# Altium schematic sheet LoRa_RF_click_v102.SchDoc
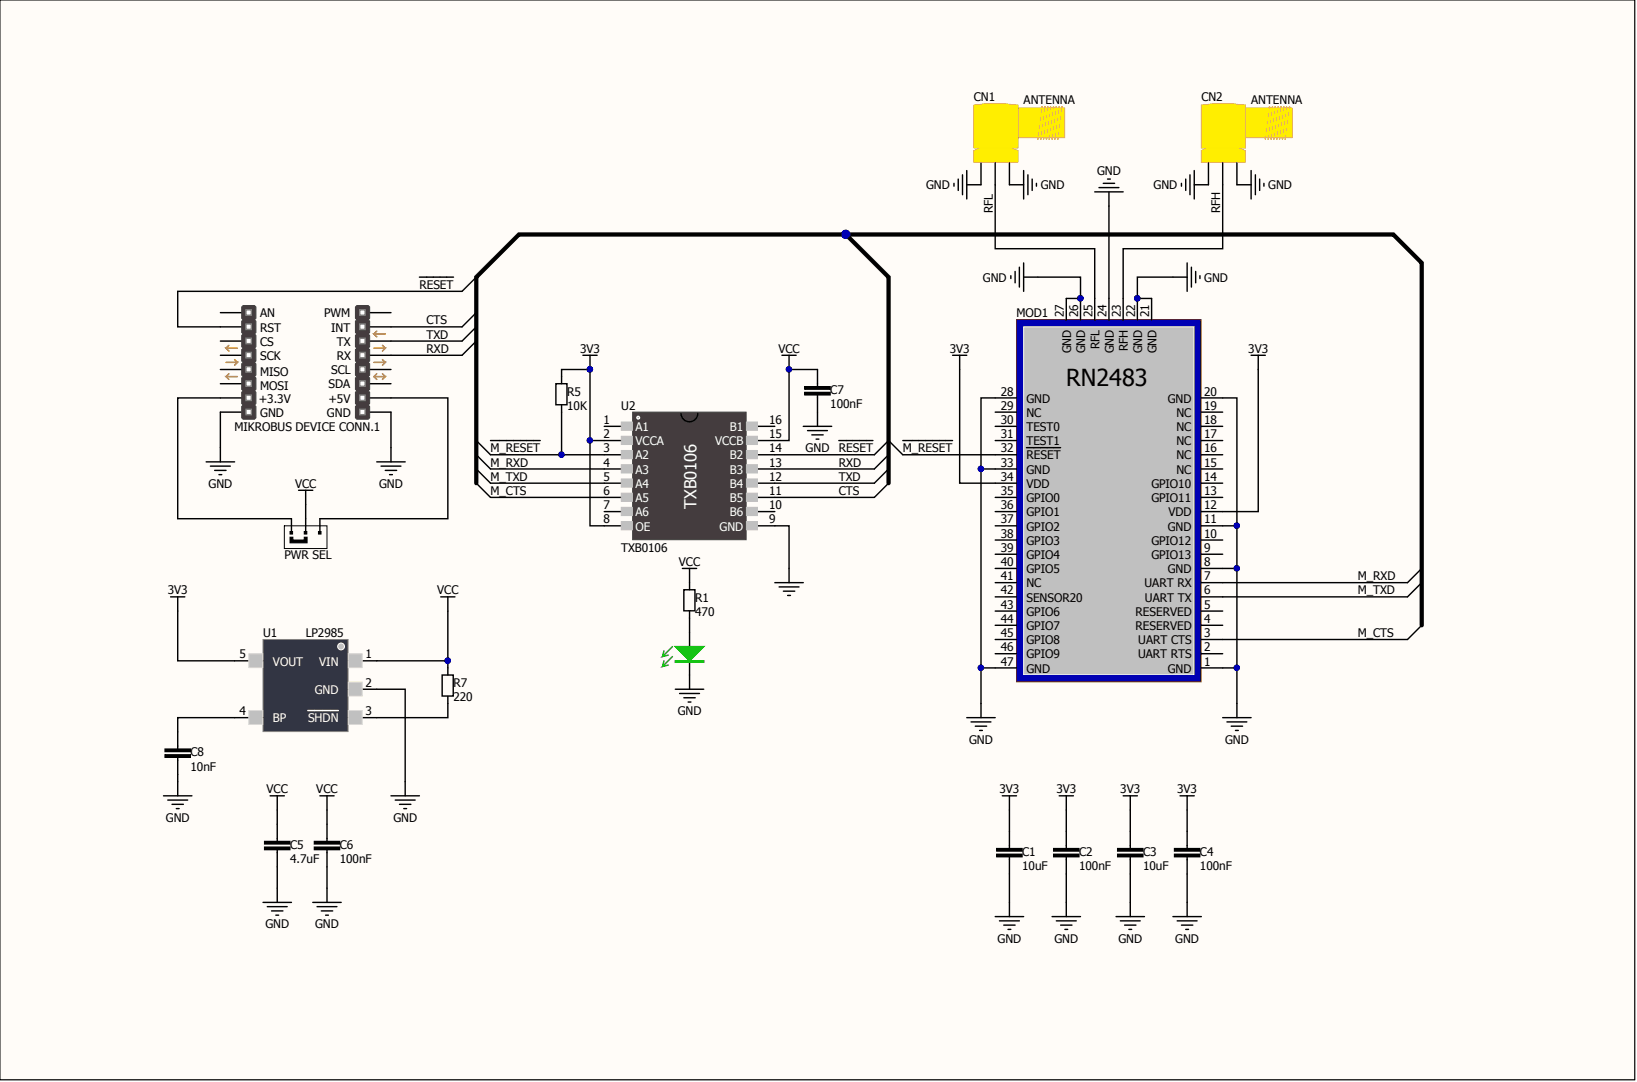
[diagram p0: LoRa_RF_click_v102.SchDoc]

## Parts
C1  10uF  [0805_C_V3]
C2  100nF  [0805_C_V3]
C3  10uF  [0805_C_V3]
C4  100nF  [0805_C_V3]
C5  4.7uF  [0805_C_V3]
C6  100nF  [0805_C_V3]
C7  100nF  [0805_C_V3]
C8  10nF  [0805_C_V3]
CN1  ANTENNA  [MCX JACK RIGH ANGLE]
CN2  ANTENNA  [MCX JACK RIGH ANGLE]
J3  PWR SEL  [0805 DUAL v2]  (part A)
MIKROBUS_DEVICE_CONN.1  MIKROBUS DEVICE CONN.  [MIKRO_BUS_LARGE]
MOD1  RN2483  [rn2483]
PWR  GREEN  [0805 - LED]  (value not drawn)  (part A)
R1  470  [0805_R_V3]
R5  10K  [0805_R_V3]
R7  220  [0805_R_V3]
U1  LP2985  [TSOT23-5]
U2  TXB0106  [TSSOP-16]

## Nets
3V3: C1.2 C2.2 C3.2 C4.2 MOD1.12(VDD) MOD1.34(VDD) R5.2 U1.5(VOUT) U2.2(VCCA) U2.8(OE)
GND: C1.1 C2.1 C3.1 C4.1 C5.1 C6.1 C7.2 C8.1 CN1.0 CN2.0 MIKROBUS_DEVICE_CONN.1.8(GND) MIKROBUS_DEVICE_CONN.1.9(GND) MOD1.1(GND) MOD1.8(GND) MOD1.11(GND) MOD1.20(GND) MOD1.21(GND) MOD1.22(GND) MOD1.24(GND) MOD1.26(GND) MOD1.27(GND) MOD1.28(GND) MOD1.33(GND) MOD1.47(GND) PWR.2 U1.2(GND) U2.9(GND)
VCC: C5.2 C6.2 C7.1 J3.2 R1.2 R7.2 U1.1(VIN) U2.15(VCCB)
CTS: MIKROBUS_DEVICE_CONN.1.15(INT) U2.11(B5)
~{M_RESET}: MOD1.32(RESET) R5.1 U2.3(A2)
M_CTS: MOD1.3(UART_CTS) U2.6(A5)
M_RXD: MOD1.7(UART_RX) U2.4(A3)
M_TXD: MOD1.6(UART_TX) U2.5(A4)
~{RESET}: MIKROBUS_DEVICE_CONN.1.2(RST) U2.14(B2)
RFH: CN2.1 MOD1.23(RFH)
RFL: CN1.1 MOD1.25(RFL)
RXD: MIKROBUS_DEVICE_CONN.1.13(RX) U2.13(B3)
TXD: MIKROBUS_DEVICE_CONN.1.14(TX) U2.12(B4)
NetC8_2: C8.2 U1.4(BP)
NetJ3_1: J3.1 MIKROBUS_DEVICE_CONN.1.7(+3.3V)
NetJ3_3: J3.3 MIKROBUS_DEVICE_CONN.1.10(+5V)
NetPWR_1: PWR.1 R1.1
NetR7_1: R7.1 U1.3(SHDN)
N00008:

Not connected: MIKROBUS_DEVICE_CONN.1 1(AN) 3(CS) 4(SCK) 5(MISO) 6(MOSI) 11(SDA) 12(SCL) 16(PWM); MOD1 2(UART_RTS) 4(RESERVED) 5(RESERVED) 9(GPIO13) 10(GPIO12) 13(GPIO11) 14(GPIO10) 15(NC) 16(NC) 17(NC) 18(NC) 19(NC) 29(NC) 30(TEST0) 31(TEST1) 35(GPIO0) 36(GPIO1) 37(GPIO2) 38(GPIO3) 39(GPIO4) 40(GPIO5) 41(NC) 42(SENSOR20) 43(GPIO6) 44(GPIO7) 45(GPIO8) 46(GPIO9); U2 1(A1) 7(A6) 10(B6) 16(B1)

## Library data of the parts
C1-C8 = CAP NP: Component Kind: Standard; SMD: Yes
  C7: Library Name: RF_click_v100.SCHLIB
  C1-C6 C8: Library Name: RTCSPI_click_v100.SchLib
CN1 CN2 = ANT_SMA: Component Kind: Standard; Description: ANT_SMA; SMD: No; Library Name: mikroE_SCH_Library_Predrag.SchLib
J3 = JUMPER2 SMD: SMD Jumper, two state; Component Kind: Standard; SMD: Yes; Library Name: AMBIENT_click_v100.SCHLIB
MIKROBUS_DEVICE_CONN.1 = MIKROBUS DEVICE CONN.: mikroBUS Target Header; Component Kind: Standard; Library Name: SCH_Rega.SchLib
MOD1 = RN2483-I/RM095: Microchip Technology Dual Band Long Range LoRa Module; Product Status: New at Mouser; Brand: Microchip Technology; Category: Microchip Technology; Component Kind: Standard; ComponentLink1Description: http://www.mouser.com/ds/2/268/70005219A-607960.pdf; ComponentLink1URL: http://www.mouser.com/ds/2/268/70005219A-607960.pdf; Library Name: RF_LoRa_click_v100.SCHLIB; Manufacturer: Microchip; Manufacturer Part Number: RN2483-I/RM095; Minimum Order Quantity: 1; Reeling: False; RoHS: RoHS Compliant; RoHS - Mouser: Y; Supplier: Mouser; Supplier 1: Mouser; Supplier Part Number: 579-RN2483-I/RM095; Supplier Part Number 1: 579-RN2483-I/RM095; Value: RN2483
PWR = LED 5 COLORS: LE diode, five colors; Component Kind: Standard; Library Name: mikroE_SchLibraryAll.lib; SMD: Yes
R1 R5 = RES: Component Kind: Standard; Library Name: RF_click_v100.SCHLIB; SMD: Yes
R7 = RES: Resistor; Component Kind: Standard; SMD: Yes; Library Name: RTCSPI_click_v100.SchLib
U1 = (no symbol name): 150 mA, 16V, High Performance LDO; Component Kind: Standard; Library Name: RN42_click_v100.SCHLIB; Supplier 1: Digi-Key; Supplier Part Number 1: 296-18476-1-ND
U2 = TXB0106: 6-Bit Bidirectional Voltage-Level Translator with Auto Direction Sensing; Component Kind: Standard; SMD: Yes; Library Name: mikroE_SCH_Library_Predrag.SchLib
